# Altium schematic sheet Sch3 - Isoladores.SchDoc("Sch3 - Isoladores")
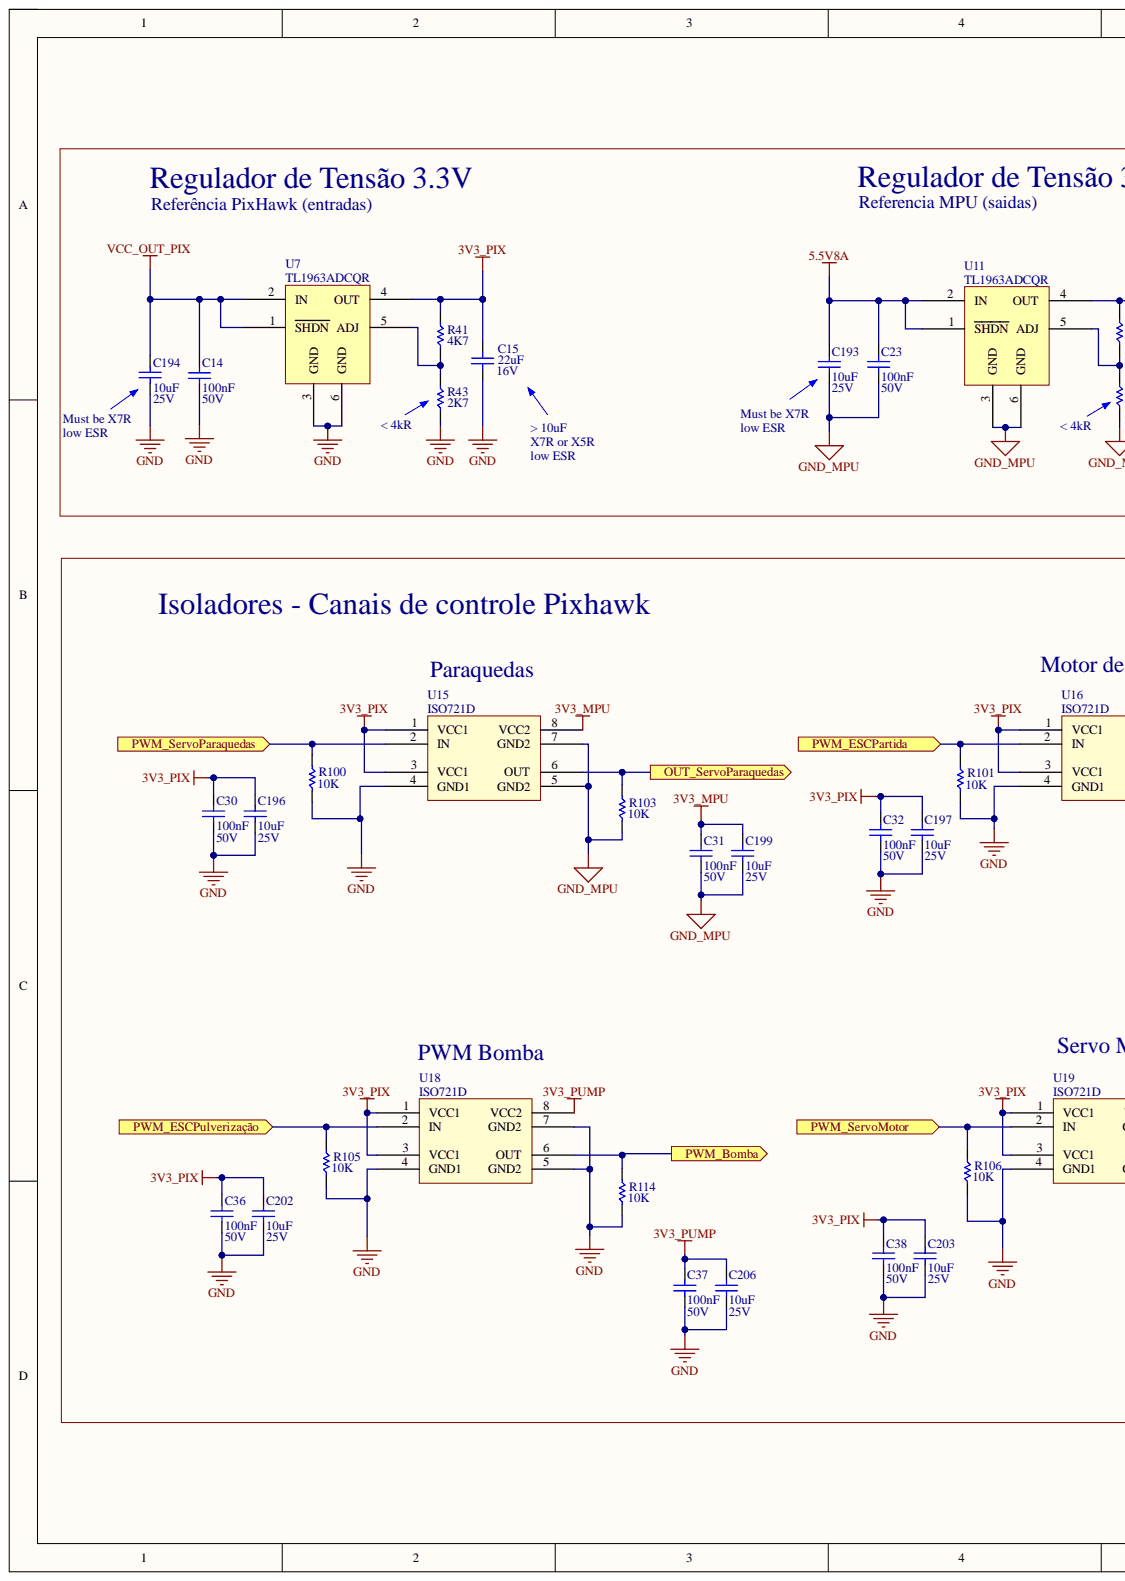
[diagram p0: Sch3 - Isoladores.SchDoc, left]
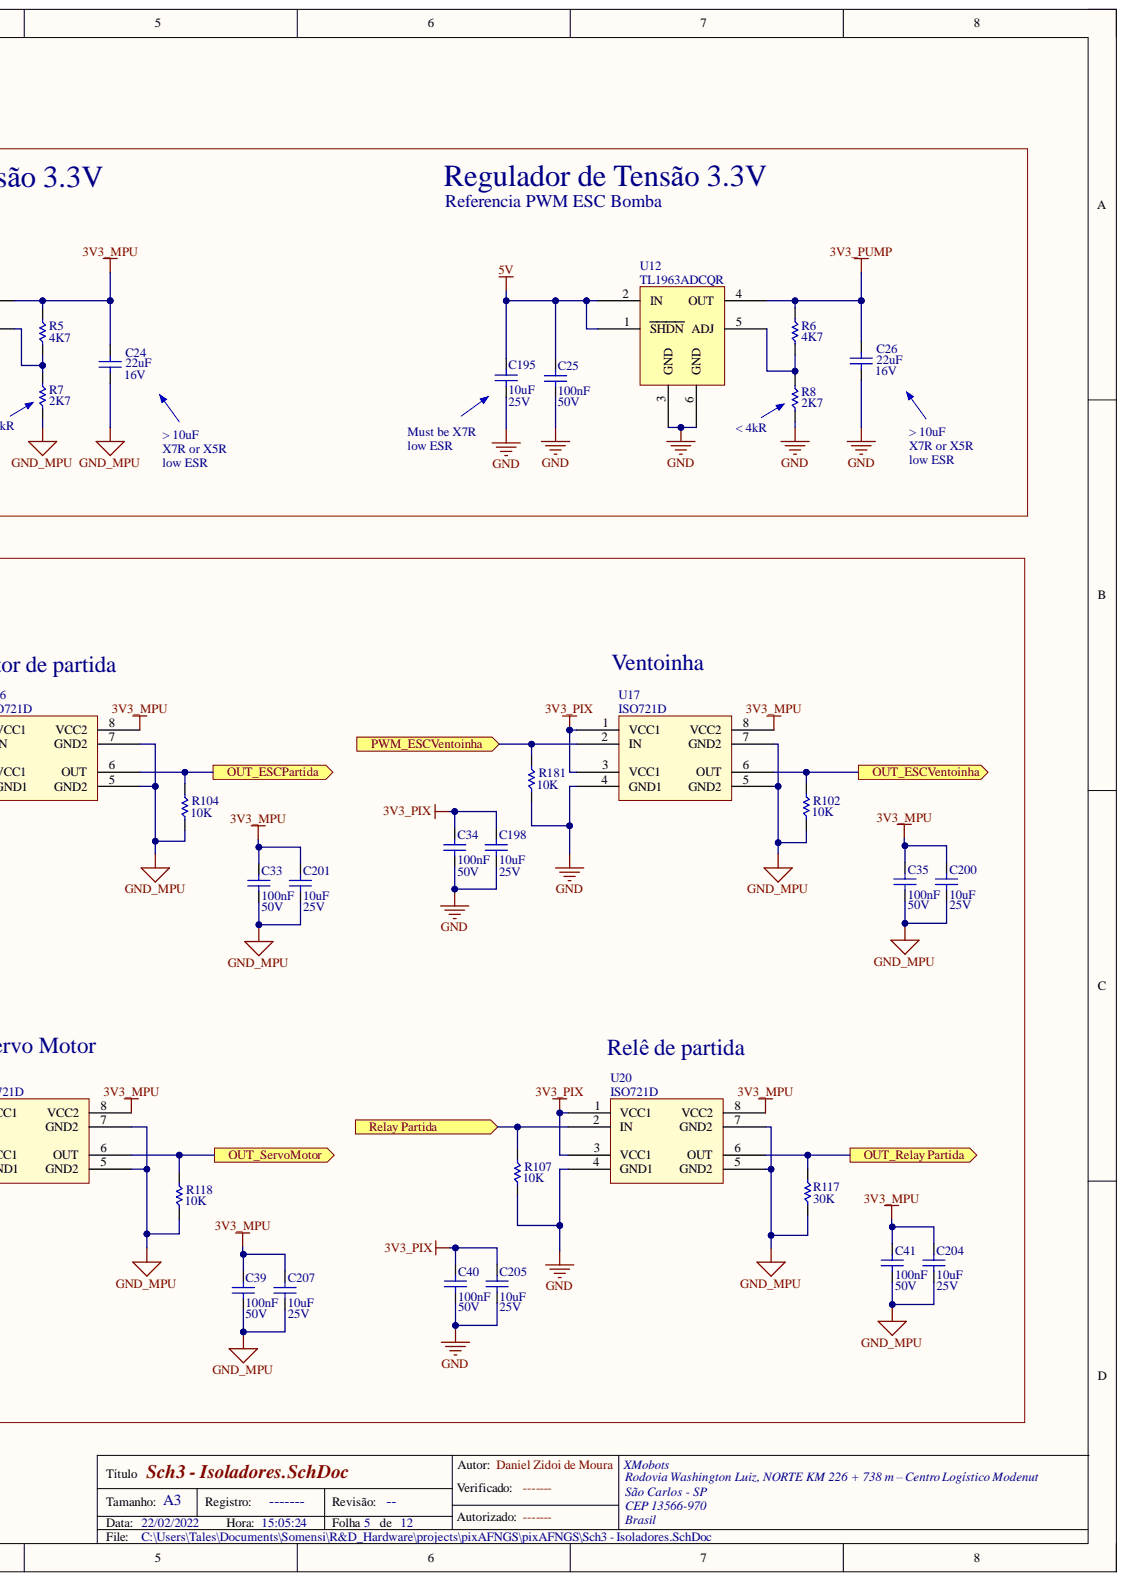
[diagram p1: Sch3 - Isoladores.SchDoc, right]

## Parts
C14  Capacitance: 100nF  Voltage: 50V  [0603]  (hidden comment: CL10B104MB8NNNC)
C15  Capacitance: 22uF  Voltage: 16V  [SMD-CER-1206]  (hidden comment: CL31A226KOCLNNC)
C23  Capacitance: 100nF  Voltage: 50V  [0603]  (hidden comment: CL10B104MB8NNNC)
C24  Capacitance: 22uF  Voltage: 16V  [SMD-CER-1206]  (hidden comment: CL31A226KOCLNNC)
C25  Capacitance: 100nF  Voltage: 50V  [0603]  (hidden comment: CL10B104MB8NNNC)
C26  Capacitance: 22uF  Voltage: 16V  [SMD-CER-1206]  (hidden comment: CL31A226KOCLNNC)
C30  Capacitance: 100nF  Voltage: 50V  [0603]  (hidden comment: CL10B104MB8NNNC)
C31  Capacitance: 100nF  Voltage: 50V  [0603]  (hidden comment: CL10B104MB8NNNC)
C32  Capacitance: 100nF  Voltage: 50V  [0603]  (hidden comment: CL10B104MB8NNNC)
C33  Capacitance: 100nF  Voltage: 50V  [0603]  (hidden comment: CL10B104MB8NNNC)
C34  Capacitance: 100nF  Voltage: 50V  [0603]  (hidden comment: CL10B104MB8NNNC)
C35  Capacitance: 100nF  Voltage: 50V  [0603]  (hidden comment: CL10B104MB8NNNC)
C36  Capacitance: 100nF  Voltage: 50V  [0603]  (hidden comment: CL10B104MB8NNNC)
C37  Capacitance: 100nF  Voltage: 50V  [0603]  (hidden comment: CL10B104MB8NNNC)
C38  Capacitance: 100nF  Voltage: 50V  [0603]  (hidden comment: CL10B104MB8NNNC)
C39  Capacitance: 100nF  Voltage: 50V  [0603]  (hidden comment: CL10B104MB8NNNC)
C40  Capacitance: 100nF  Voltage: 50V  [0603]  (hidden comment: CL10B104MB8NNNC)
C41  Capacitance: 100nF  Voltage: 50V  [0603]  (hidden comment: CL10B104MB8NNNC)
C193  Capacitance: 10uF  Voltage: 25V  [0603]  (hidden comment: GRM188R61E106KA73J)
C194  Capacitance: 10uF  Voltage: 25V  [0603]  (hidden comment: GRM188R61E106KA73J)
C195  Capacitance: 10uF  Voltage: 25V  [0603]  (hidden comment: GRM188R61E106KA73J)
C196  Capacitance: 10uF  Voltage: 25V  [CAP-XMO-0603]  (hidden comment: GRM188R61E106KA73J)
C197  Capacitance: 10uF  Voltage: 25V  [CAP-XMO-0603]  (hidden comment: GRM188R61E106KA73J)
C198  Capacitance: 10uF  Voltage: 25V  [CAP-XMO-0603]  (hidden comment: GRM188R61E106KA73J)
C199  Capacitance: 10uF  Voltage: 25V  [CAP-XMO-0603]  (hidden comment: GRM188R61E106KA73J)
C200  Capacitance: 10uF  Voltage: 25V  [CAP-XMO-0603]  (hidden comment: GRM188R61E106KA73J)
C201  Capacitance: 10uF  Voltage: 25V  [CAP-XMO-0603]  (hidden comment: GRM188R61E106KA73J)
C202  Capacitance: 10uF  Voltage: 25V  [CAP-XMO-0603]  (hidden comment: GRM188R61E106KA73J)
C203  Capacitance: 10uF  Voltage: 25V  [CAP-XMO-0603]  (hidden comment: GRM188R61E106KA73J)
C204  Capacitance: 10uF  Voltage: 25V  [CAP-XMO-0603]  (hidden comment: GRM188R61E106KA73J)
C205  Capacitance: 10uF  Voltage: 25V  [CAP-XMO-0603]  (hidden comment: GRM188R61E106KA73J)
C206  Capacitance: 10uF  Voltage: 25V  [CAP-XMO-0603]  (hidden comment: GRM188R61E106KA73J)
C207  Capacitance: 10uF  Voltage: 25V  [CAP-XMO-0603]  (hidden comment: GRM188R61E106KA73J)
R5  Resistance: 4K7  [Res_0603]  (hidden comment: RMCF0603FT4K70)
R6  Resistance: 4K7  [Res_0603]  (hidden comment: RMCF0603FT4K70)
R7  Resistance: 2K7  [Res_0603]  (hidden comment: CRGCQ0603F2K7)
R8  Resistance: 2K7  [Res_0603]  (hidden comment: CRGCQ0603F2K7)
R41  Resistance: 4K7  [Res_0603]  (hidden comment: RMCF0603FT4K70)
R43  Resistance: 2K7  [Res_0603]  (hidden comment: CRGCQ0603F2K7)
R100  Resistance: 10K  [RES-XMO-0603]  (hidden comment: RMCF0603FT10K0)
R101  Resistance: 10K  [RES-XMO-0603]  (hidden comment: RMCF0603FT10K0)
R102  Resistance: 10K  [RES-XMO-0603]  (hidden comment: RMCF0603FT10K0)
R103  Resistance: 10K  [RES-XMO-0603]  (hidden comment: RMCF0603FT10K0)
R104  Resistance: 10K  [RES-XMO-0603]  (hidden comment: RMCF0603FT10K0)
R105  Resistance: 10K  [RES-XMO-0603]  (hidden comment: RMCF0603FT10K0)
R106  Resistance: 10K  [RES-XMO-0603]  (hidden comment: RMCF0603FT10K0)
R107  Resistance: 10K  [RES-XMO-0603]  (hidden comment: RMCF0603FT10K0)
R114  Resistance: 10K  [RES-XMO-0603]  (hidden comment: RMCF0603FT10K0)
R117  Resistance: 30K  [RES-XMO-0603]  (hidden comment: RMCF0603FT30K0)
R118  Resistance: 10K  [RES-XMO-0603]  (hidden comment: RMCF0603FT10K0)
R181  Resistance: 10K  [RES-XMO-0603]  (hidden comment: RMCF0603FT10K0)
U7  TL1963ADCQR  [SOT127_R-PDSO-G6]
U11  TL1963ADCQR  [SOT127_R-PDSO-G6]
U12  TL1963ADCQR  [SOT127_R-PDSO-G6]
U15  ISO721D  [SOIC-8_D-PDSO-G8]
U16  ISO721D  [SOIC-8_D-PDSO-G8]
U17  ISO721D  [SOIC-8_D-PDSO-G8]
U18  ISO721D  [SOIC-8_D-PDSO-G8]
U19  ISO721D  [SOIC-8_D-PDSO-G8]
U20  ISO721D  [SOIC-8_D-PDSO-G8]

## Ports
OUT_ESCPartida, OUT_ESCVentoinha, OUT_Relay Partida, OUT_ServoMotor, OUT_ServoParaquedas, PWM_Bomba, PWM_ESCPartida, PWM_ESCPulverização, PWM_ESCVentoinha, PWM_ServoMotor, PWM_ServoParaquedas, Relay Partida

## Nets
3V3_MPU: C24.2 C31.2 C33.2 C35.2 C39.2 C41.2 C199.2 C200.2 C201.2 C204.2 C207.2 R5.2 U11.4(OUT) U15.8(VCC2) U16.8(VCC2) U17.8(VCC2) U19.8(VCC2) U20.8(VCC2)
3V3_PIX: C15.2 C30.2 C32.2 C34.2 C36.2 C38.2 C40.2 C196.2 C197.2 C198.2 C202.2 C203.2 C205.2 R41.2 U7.4(OUT) U15.1(VCC1) U15.3(VCC1) U16.1(VCC1) U16.3(VCC1) U17.1(VCC1) U17.3(VCC1) U18.1(VCC1) U18.3(VCC1) U19.1(VCC1) U19.3(VCC1) U20.1(VCC1) U20.3(VCC1)
3V3_PUMP: C26.2 C37.2 C206.2 R6.2 U12.4(OUT) U18.8(VCC2)
5.5V8A: C23.2 C193.2 U11.1(SHDN) U11.2(IN)
5V: C25.2 C195.2 U12.1(SHDN) U12.2(IN)
GND: C14.1 C15.1 C25.1 C26.1 C30.1 C32.1 C34.1 C36.1 C37.1 C38.1 C40.1 C194.1 C195.1 C196.1 C197.1 C198.1 C202.1 C203.1 C205.1 C206.1 R8.1 R43.1 R100.2 R101.2 R105.2 R106.2 R107.2 R114.2 R181.2 U7.3(GND) U7.6(GND) U12.3(GND) U12.6(GND) U15.4(GND1) U16.4(GND1) U17.4(GND1) U18.4(GND1) U18.5(GND2) U18.7(GND2) U19.4(GND1) U20.4(GND1)
GND_MPU: C23.1 C24.1 C31.1 C33.1 C35.1 C39.1 C41.1 C193.1 C199.1 C200.1 C201.1 C204.1 C207.1 R7.1 R102.2 R103.2 R104.2 R117.1 R118.2 U11.3(GND) U11.6(GND) U15.5(GND2) U15.7(GND2) U16.5(GND2) U16.7(GND2) U17.5(GND2) U17.7(GND2) U19.5(GND2) U19.7(GND2) U20.5(GND2) U20.7(GND2)
VCC_OUT_PIX: C14.2 C194.2 U7.1(SHDN) U7.2(IN)
OUT_ESCPartida: R104.1 U16.6(OUT) ; port OUT_ESCPartida
OUT_ESCVentoinha: R102.1 U17.6(OUT) ; port OUT_ESCVentoinha
OUT_Relay Partida: R117.2 U20.6(OUT) ; port OUT_Relay Partida
OUT_ServoMotor: R118.1 U19.6(OUT) ; port OUT_ServoMotor
OUT_ServoParaquedas: R103.1 U15.6(OUT) ; port OUT_ServoParaquedas
PWM_Bomba: R114.1 U18.6(OUT) ; port PWM_Bomba
PWM_ESCPartida: R101.1 U16.2(IN) ; port PWM_ESCPartida
PWM_ESCPulverização: R105.1 U18.2(IN) ; port PWM_ESCPulverização
PWM_ESCVentoinha: R181.1 U17.2(IN) ; port PWM_ESCVentoinha
PWM_ServoMotor: R106.1 U19.2(IN) ; port PWM_ServoMotor
PWM_ServoParaquedas: R100.1 U15.2(IN) ; port PWM_ServoParaquedas
Relay Partida: R107.1 U20.2(IN) ; port Relay Partida
NetR5_1: R5.1 R7.2 U11.5(ADJ)
NetR6_1: R6.1 R8.2 U12.5(ADJ)
NetR41_1: R41.1 R43.2 U7.5(ADJ)

## Library data of the parts
C14 C23 C25 C30-C41 = C-100nF-50V-X7R-0603: Capacitor 100nF 50V X7R 0603; Component Kind: Standard; Part Number: CL10B104MB8NNNC; Library Name: xmobots_-_comp_library.IntLib
C15 C24 C26 = C-22uF-16V-X5R-1206: Capacitor 22uF 16V X5R 1206; Component Kind: Standard; Part Number: CL31A226KOCLNNC; Library Name: xmobots_-_comp_library.IntLib
C193-C207 = C-CER-10uF-25V-X5R-0603: Capacitor 10uF 25V X5R 0603; Component Kind: Standard; Part Number: GRM188R61E106KA73J; Library Name: xmobots_-_comp_library.IntLib
R5 R6 R41 = R-4K7-1/10W-1%-0603: Resistor 4k7 ohms 1/10W 1% 0603; Component Kind: Standard; Part Number: RMCF0603FT4K70; Power: 1/10W; Tolerance: ±1%; Library Name: xmobots_-_comp_library.IntLib
R7 R8 R43 = R-2K7-1/10W-1%-0603: Resistor 2k7 ohms 1/10W 1% 0603; Component Kind: Standard; Part Number: CRGCQ0603F2K7; Power: 1/10W; Tolerance: ±1%; Library Name: xmobots_-_comp_library.IntLib
R100-R107 R114 R118 R181 = R-10K-1/10W-1%-0603: Resistor 10k ohms 1/10W 1% 0603; Component Kind: Standard; Part Number: RMCF0603FT10K0; Power: 1/10W; Tolerance: ±1%; Library Name: xmobots_-_comp_library.IntLib
R117 = R-30K-1/10W-1%-0603: Resistor 30k ohms 1/10W 1% 0603; Component Kind: Standard; Part Number: RMCF0603FT30K0; Power: 1/10W; Tolerance: ±1%; Library Name: xmobots_-_comp_library.IntLib
U7 U11 U12 = TL1963ADCQR: 1.5-A LOW-NOISE FAST-TRANSIENT-RESPONSE LOW-DROPOUT REGULATOR; Component Kind: Standard; Supplier 1: Digi-Key; Supplier Part Number 1: 296-25119-1-ND; Library Name: XM_IC.SchLib
U15-U20 = ISO721D: 3.3-V / 5-V HIGH-SPEED DIGITAL ISOLATORS; Component Kind: Standard; Supplier 1: Digi-Key; Supplier Part Number 1: 296-19382-ND; Library Name: XM_IC.SchLib
